# Altium schematic sheet Audio.SchDoc(Audio)
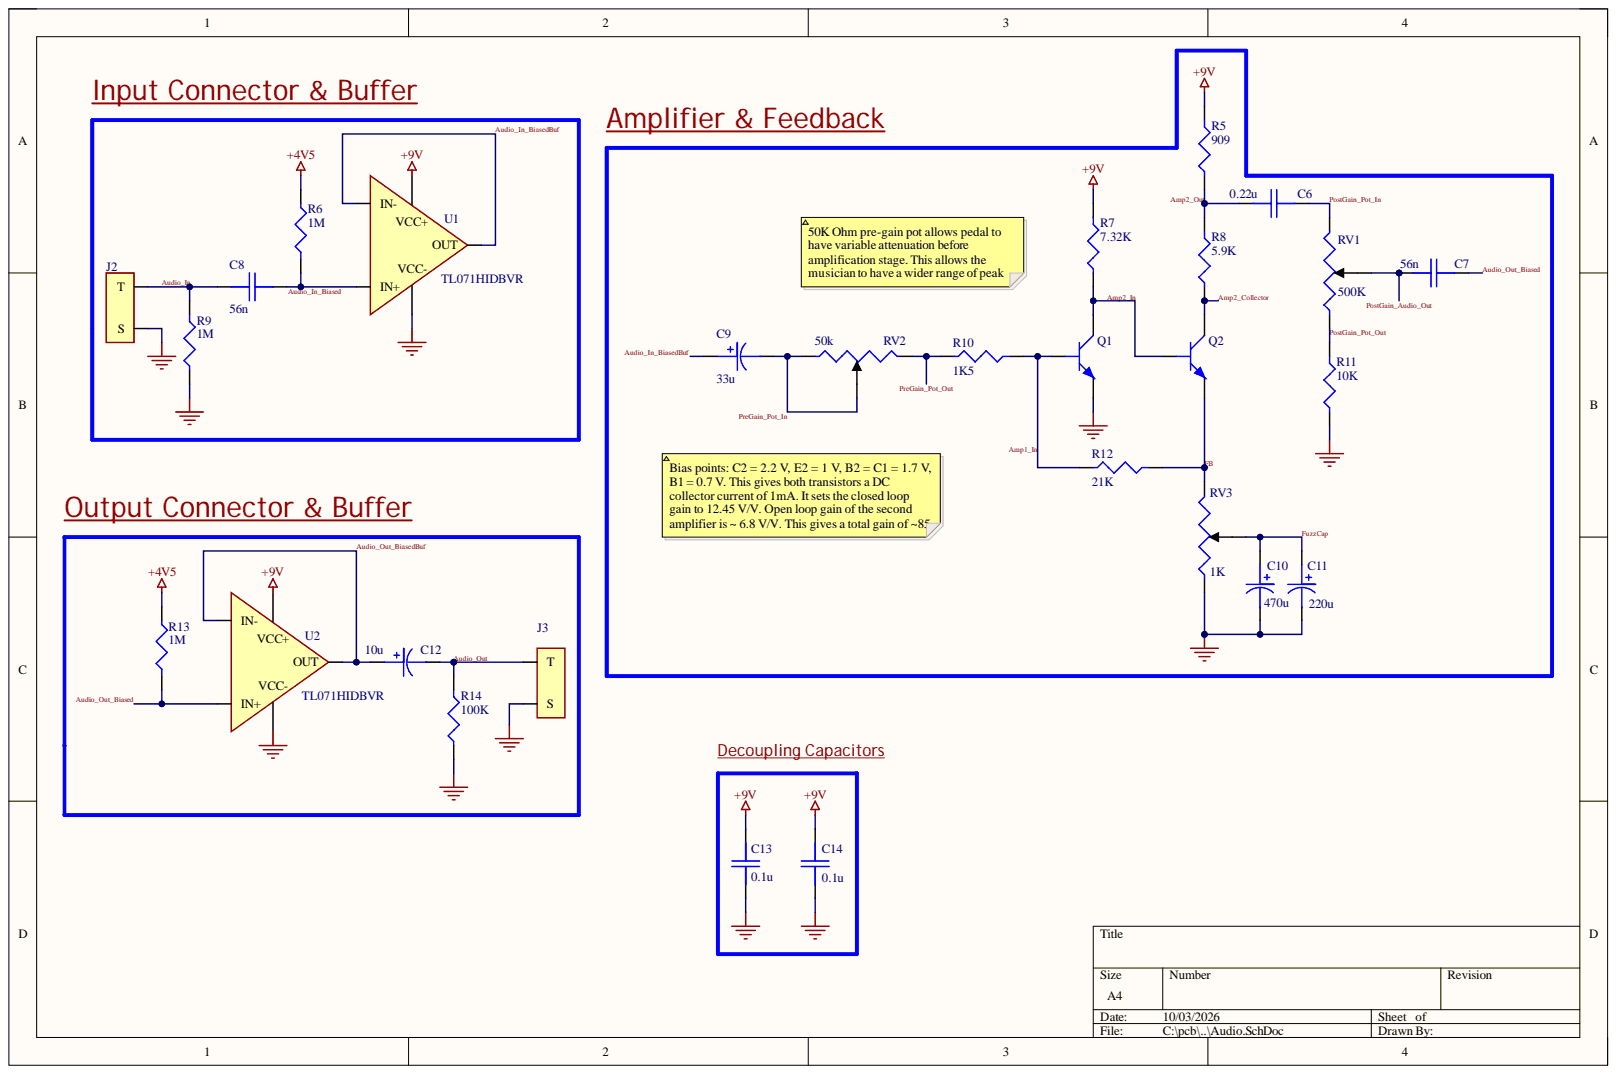
[diagram p0: Audio.SchDoc]

## Parts
C6  0.22u  [R82DC3220AA60K]
C7  56n  [R82EC2560SH60J]
C8  56n  [R82EC2560SH60J]
C9  33u  [UES1H330MPM1TD]
C10  470u  [UFW1V471MPD1TD]
C11  220u  [UFW1E221MPD1TD]
C12  10u  [UCS2G100MPD1TD]
C13  0.1u  [C0603]
C14  0.1u  [C0603]
J2  RA49B11  [RA49B11]  (value not drawn)
J3  RA49B11  [RA49B11]  (value not drawn)
Q1  MMBT2222ALT1G  [MMBT2222ALT1G]  (value not drawn)
Q2  MMBT2222ALT1G  [MMBT2222ALT1G]  (value not drawn)
R5  909  [R0805]
R6  1M  [R0805]
R7  7.32K  [R0805]
R8  5.9K  [R0805]
R9  1M  [R0805]
R10  1K5  [R0805]
R11  10K  [R0805]
R12  21K  [R0805]
R13  1M  [R0805]
R14  100K  [R0805]
RV1  500K  [RV16106NO-KA17D8.5-B500K-060C]
RV2  50k  [RV16106NO-KA17D8.5-B50K-060C]  (no BOM)
RV3  1K  [RV16AF-41-17K-B1K]
U1  TL071HIDBVR  [TL071HIDBVR]
U2  TL071HIDBVR  [TL071HIDBVR]

## Nets
+4V5: R6.1 R13.1
+9V: C13.2 C14.2 R5.2 R7.2 U1.5 U2.5
GND: C10.2 C11.2 C13.1 C14.1 J2.2 J3.2 Q1.2 R9.1 R11.1 R14.1 RV3.1 U1.2 U2.2
Amp1_In: Q1.1 R10.2 R12.1
Amp2_Collector: Q2.3 R8.1
Amp2_In: Q1.3 Q2.1 R7.1
Amp2_Out: C6.1 R5.1 R8.2
Audio_In: C8.1 J2.1 R9.2
Audio_In_Biased: C8.2 R6.2 U1.3(IN+)
Audio_In_BiasedBuf: C9.1 U1.1(OUT) U1.4(IN-)
Audio_Out: C12.2 J3.1 R14.2
Audio_Out_Biased: C7.2 R13.2 U2.3(IN+)
Audio_Out_BiasedBuf: C12.1 U2.1(OUT) U2.4(IN-)
FB: Q2.2 R12.2 RV3.3
FuzzCap: C10.1 C11.1 RV3.2
PostGain_Audio_Out: C7.1 RV1.2
PostGain_Pot_In: C6.2 RV1.3
PostGain_Pot_Out: R11.2 RV1.1
PreGain_Pot_In: C9.2 RV2.1 RV2.2
PreGain_Pot_Out: R10.1 RV2.3
